# Altium schematic sheet SoC_PS.SchDoc
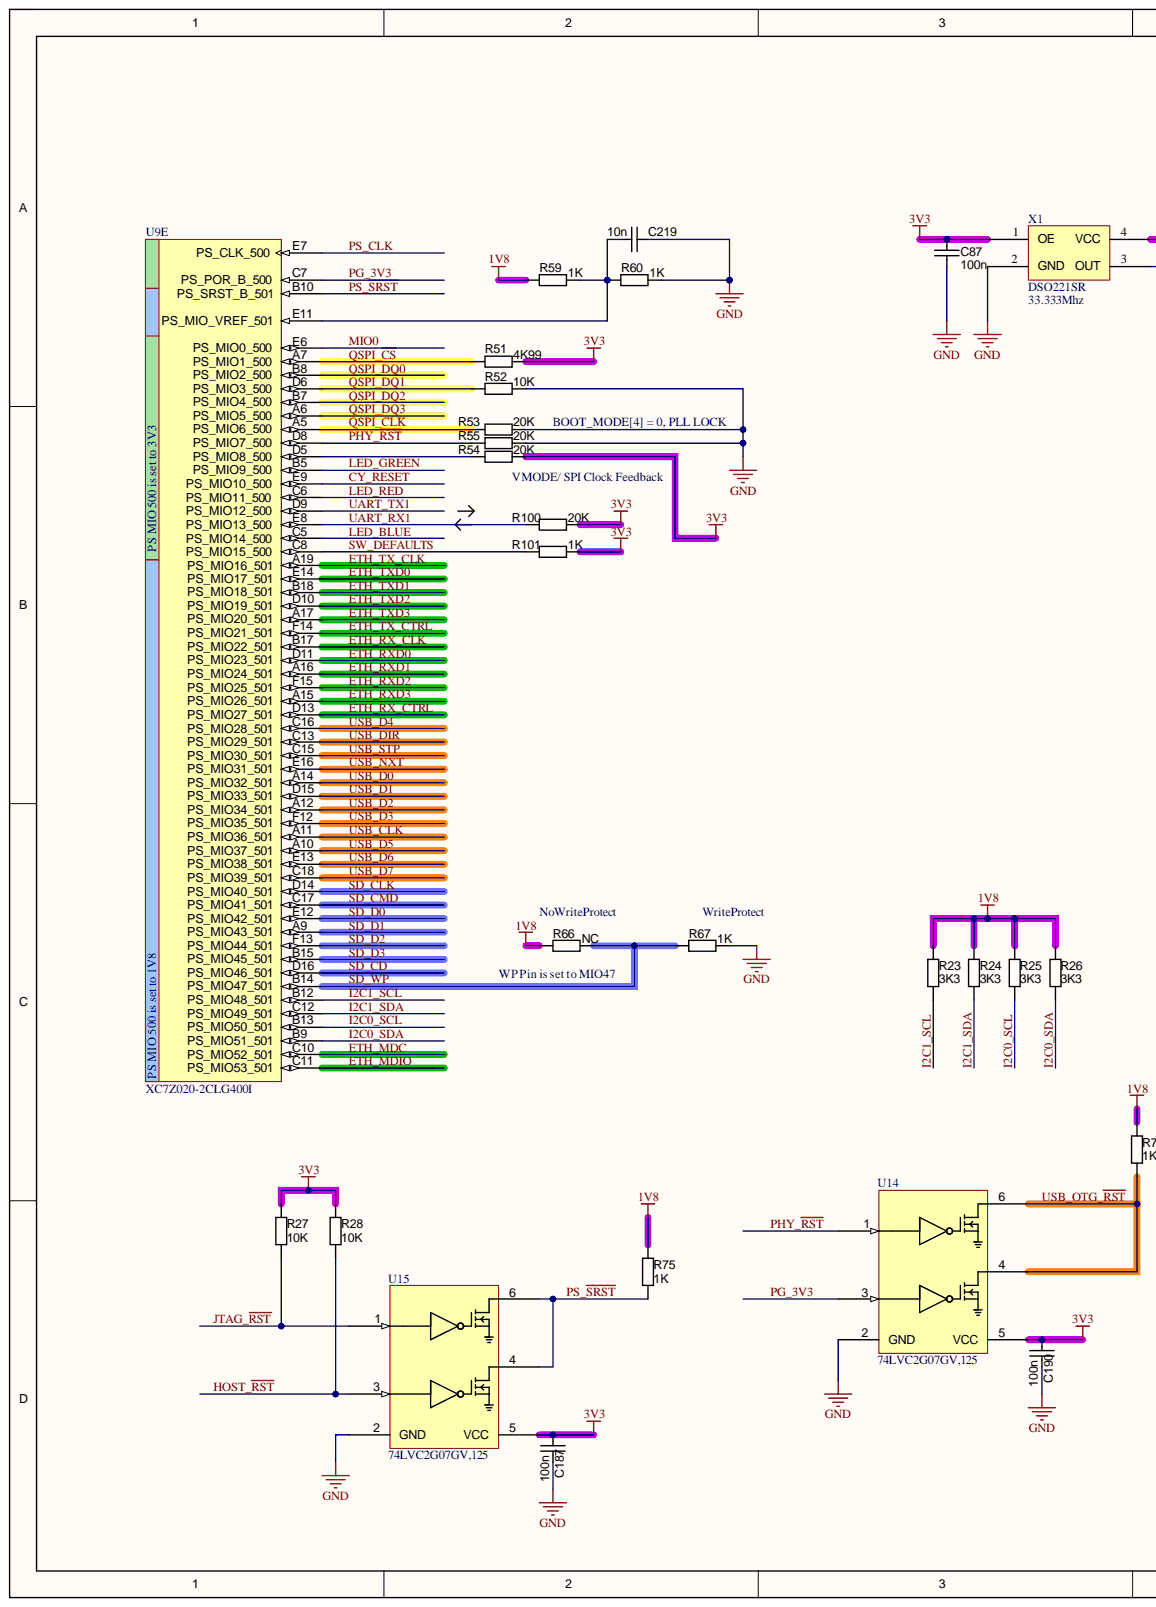
[diagram p0: SoC_PS.SchDoc, left]
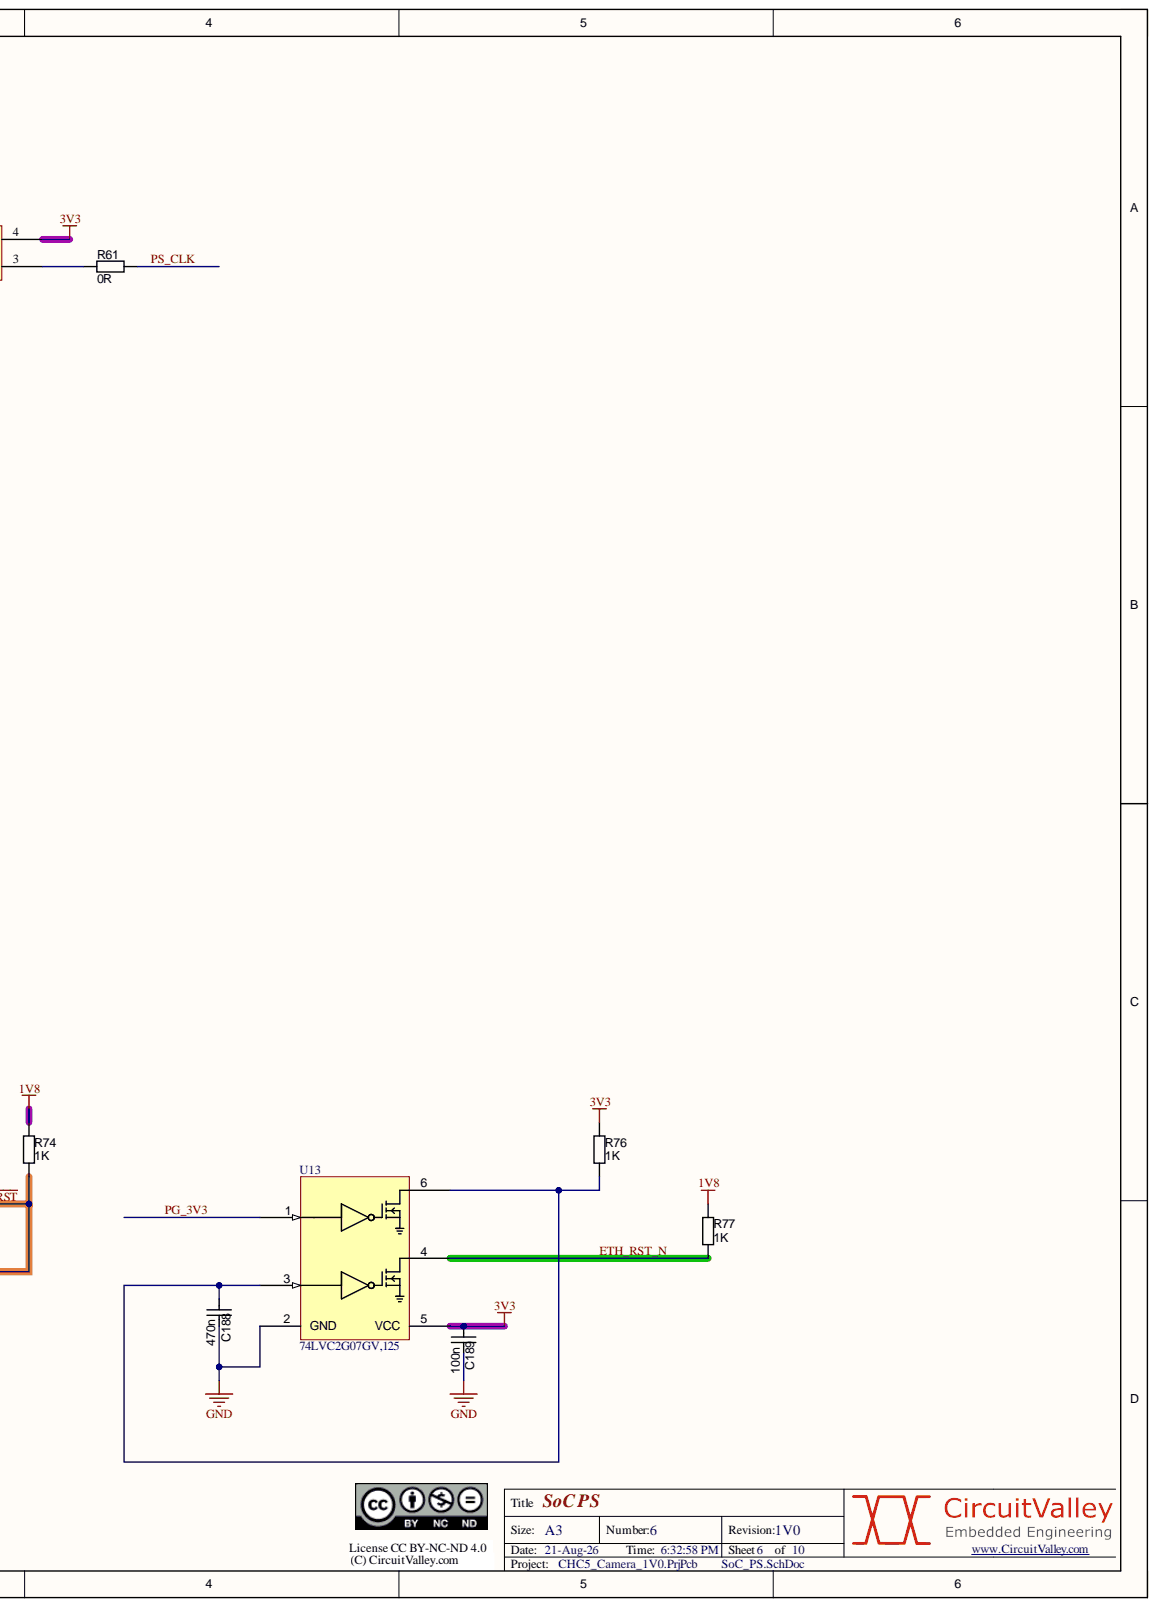
[diagram p1: SoC_PS.SchDoc, right]

## Parts
C87  100n  [CAPC1005N]
C187  100n  [CAPC1005N]
C188  470n  [CAPC1005N]
C189  100n  [CAPC1005N]
C190  100n  [CAPC1005N]
C219  10n  [CAPC1005N]
R23  value 3K3  [RESC1005N]  (hidden comment: Thick Film)
R24  value 3K3  [RESC1005N]  (hidden comment: Thick Film)
R25  value 3K3  [RESC1005N]  (hidden comment: Thick Film)
R26  value 3K3  [RESC1005N]  (hidden comment: Thick Film)
R27  value 10K  [RESC1005N]  (hidden comment: Thick Film)
R28  value 10K  [RESC1005N]  (hidden comment: Thick Film)
R51  value 4K99  [RESC1005N]  (hidden comment: Thick Film)
R52  value 10K  [RESC1005N]  (hidden comment: Thick Film)
R53  value 20K  [RESC1005N]  (hidden comment: Thick Film)
R54  value 20K  [RESC1005N]  (hidden comment: Thick Film)
R55  value 20K  [RESC1005N]  (hidden comment: Thick Film)
R59  value 1K  [RESC1005N]  (hidden comment: Thick Film)
R60  value 1K  [RESC1005N]  (hidden comment: Thick Film)
R61  value 0R  [RESC1005N]  (hidden comment: Thick Film)
R66  value NC  [RESC1005N]  (hidden comment: Thick Film)
R67  value 1K  [RESC1005N]  (hidden comment: Thick Film)
R74  value 1K  [RESC1005N]  (hidden comment: Thick Film)
R75  value 1K  [RESC1005N]  (hidden comment: Thick Film)
R76  value 1K  [RESC1005N]  (hidden comment: Thick Film)
R77  value 1K  [RESC1005N]  (hidden comment: Thick Film)
R100  value 20K  [RESC1005N]  (hidden comment: Thick Film)
R101  value 1K  [RESC1005N]  (hidden comment: Thick Film)
U9  XC7Z020-2CLG400I  [CLG400]  (part E)
U13  74LVC2G07GV,125  [SC74-6]
U14  74LVC2G07GV,125  [SC74-6]
U15  74LVC2G07GV,125  [SC74-6]
X1  DSO221SR  value 33.333Mhz  [Active Oscillator 2520]

## Nets
1V8: R23.2 R24.2 R25.2 R26.2 R59.1 R66.1 R74.2 R75.2 R77.2
3V3: C87.2 C187.2 C189.2 C190.2 R27.2 R28.2 R51.2 R54.2 R76.2 R100.2 R101.2 U13.5(VCC) U14.5(VCC) U15.5(VCC) X1.1(OE) X1.4(VCC)
GND: C87.1 C187.1 C188.1 C189.1 C190.1 C219.1 R52.2 R53.2 R55.2 R60.2 R67.2 U13.2(GND) U14.2(GND) U15.2(GND) X1.2(GND)
CY_RESET: U9.E9(PS_MIO10_500)
ETH_MDC: U9.C10(PS_MIO52_501)
ETH_MDIO: U9.C11(PS_MIO53_501)
ETH_RST_N: R77.1 U13.4
ETH_RX_CLK: U9.B17(PS_MIO22_501)
ETH_RX_CTRL: U9.D13(PS_MIO27_501)
ETH_RXD0: U9.D11(PS_MIO23_501)
ETH_RXD1: U9.A16(PS_MIO24_501)
ETH_RXD2: U9.F15(PS_MIO25_501)
ETH_RXD3: U9.A15(PS_MIO26_501)
ETH_TX_CLK: U9.A19(PS_MIO16_501)
ETH_TX_CTRL: U9.F14(PS_MIO21_501)
ETH_TXD0: U9.E14(PS_MIO17_501)
ETH_TXD1: U9.B18(PS_MIO18_501)
ETH_TXD2: U9.D10(PS_MIO19_501)
ETH_TXD3: U9.A17(PS_MIO20_501)
HOST_~{RST}: R28.1 U15.3
I2C0_SCL: R25.1 U9.B13(PS_MIO50_501)
I2C0_SDA: R26.1 U9.B9(PS_MIO51_501)
I2C1_SCL: R23.1 U9.B12(PS_MIO48_501)
I2C1_SDA: R24.1 U9.C12(PS_MIO49_501)
JTAG_~{RST}: R27.1 U15.1
LED_BLUE: U9.C5(PS_MIO14_500)
LED_GREEN: U9.B5(PS_MIO9_500)
LED_RED: U9.C6(PS_MIO11_500)
MIO0: U9.E6(PS_MIO0_500)
PG_3V3: U9.C7(PS_POR_B_500) U13.1 U14.3
PHY_~{RST}: R55.1 U9.D8(PS_MIO7_500) U14.1
PS_CLK: R61.2 U9.E7(PS_CLK_500)
PS_~{SRST}: R75.1 U9.B10(PS_SRST_B_501) U15.4 U15.6
QSPI_~{CS}: R51.1 U9.A7(PS_MIO1_500)
QSPI_CLK: R53.1 U9.A5(PS_MIO6_500)
QSPI_DQ0: U9.B8(PS_MIO2_500)
QSPI_DQ1: R52.1 U9.D6(PS_MIO3_500)
QSPI_DQ2: U9.B7(PS_MIO4_500)
QSPI_DQ3: U9.A6(PS_MIO5_500)
SD_CD: U9.D16(PS_MIO46_501)
SD_CLK: U9.D14(PS_MIO40_501)
SD_CMD: U9.C17(PS_MIO41_501)
SD_D0: U9.E12(PS_MIO42_501)
SD_D1: U9.A9(PS_MIO43_501)
SD_D2: U9.F13(PS_MIO44_501)
SD_D3: U9.B15(PS_MIO45_501)
SD_WP: R66.2 R67.1 U9.B14(PS_MIO47_501)
SW_DEFAULTS: R101.1 U9.C8(PS_MIO15_500)
UART_RX1: R100.1 U9.E8(PS_MIO13_500)
UART_TX1: U9.D9(PS_MIO12_500)
USB_CLK: U9.A11(PS_MIO36_501)
USB_D0: U9.A14(PS_MIO32_501)
USB_D1: U9.D15(PS_MIO33_501)
USB_D2: U9.A12(PS_MIO34_501)
USB_D3: U9.F12(PS_MIO35_501)
USB_D4: U9.C16(PS_MIO28_501)
USB_D5: U9.A10(PS_MIO37_501)
USB_D6: U9.E13(PS_MIO38_501)
USB_D7: U9.C18(PS_MIO39_501)
USB_DIR: U9.C13(PS_MIO29_501)
USB_NXT: U9.E16(PS_MIO31_501)
USB_OTG_~{RST}: R74.1 U14.4 U14.6
USB_STP: U9.C15(PS_MIO30_501)
NetC188_2: C188.2 R76.1 U13.3 U13.6
NetC219_2: C219.2 R59.2 R60.1 U9.E11(PS_MIO_VREF_501)
NetR54_1: R54.1 U9.D5(PS_MIO8_500)
NetR61_1: R61.1 X1.3(OUT)

## Library data of the parts
C87 C187-C190 C219 = CAPC_10n_0402_16V_10%: Capacitor SMD Cer 10nF 10% 16V X7R 0402; Manufacturer 1: AVX Corporation; Manufacturer Part Number 1: 0402YC103KAT2A; Ratings: 16V; X7R; Component Kind: Standard; ComponentLink1Description: http://www.avx.com/docs/Catalogs/cx7r.pdf; ComponentLink1URL: http://www.avx.com/docs/Catalogs/cx7r.pdf; ComponentLink2Description: http://www.yageo.com/documents/recent/UPY-GPHC_X7R_6.3V-to-50V_8.pdf; ComponentLink2URL: http://www.yageo.com/documents/recent/UPY-GPHC_X7R_6.3V-to-50V_8.pdf; Library Name: PBL - Capacitors.SCHLIB; Manufacturer 2: Yageo; Manufacturer Part Number 2: CC0402KRX7R7BB103; Operating Temperature: -55°C ~ 125°C; Package / Case: 0402 (1005 Metric); Size / Dimension: 0.039" L x 0.020" W (1.00mm x 0.50mm); Supplier 1: Digi-Key; Supplier 2: Digi-Key; Supplier Part Number 1: 478-1114-1-ND; Supplier Part Number 2: 311-1042-1-ND; Tolerance: ±10%
  C87 C187 C189 C190: Value: 100n
  C188: Value: 470n
  C219: Value: 10n
R23-R28 R51-R55 R59-R61 R66 R67 R74-R77 R100 R101 = RES_3K3_0402_5%: Resistor SMD chip 3.3k Ohm 0.063W 5% 0402; Manufacturer 1: Yageo; Manufacturer Part Number 1: RC0402JR-073K3L; Ratings: 1/16 W; Component Kind: Standard; ComponentLink1Description: http://www.yageo.com/documents/recent/PYu-RC0402_51_RoHS_L_6.pdf; ComponentLink1URL: http://www.yageo.com/documents/recent/PYu-RC0402_51_RoHS_L_6.pdf; Library Name: PBL - Resistors.SCHLIB; Material: Thick Film; Package / Case: 0402 (1005 metric); Supplier 1: Digi-Key; Supplier Part Number 1: 311-3.3KJRCT-ND; Tolerance: ±5%
U9 = XC7Z020-2CLG400I: 733 MHz Zynq™-7000 AP SoC, 130 PS I/O, 125 FPGA I/O, 400-Ball BGA, Speed Grade 2, Industrial Grade, Pb-Free; Manufacturer: Xilinx; Extensible Block RAM (# 36Kb Blocks): 560 KB (140); Flip Flops: 106400; LUTs: 53200; Speed Grade: -2; Code_JEDEC: MO-275-MMAA-1; Component Kind: Standard; ComponentLink1Description: Datasheet; ComponentLink1URL: http://www.xilinx.com/support/documentation/data_sheets/ds190-Zynq-7000-Overview.pdf; ComponentLink2Description: Package Specification; ComponentLink2URL: http://www.xilinx.com/support/documentation/user_guides/ug865-Zynq-7000-Pkg-Pinout.pdf; ComponentLink3Description: DC and AC Switching Characteristics; ComponentLink3URL: http://www.xilinx.com/support/documentation/data_sheets/ds187-XC7Z010-XC7Z020-Data-Sheet.pdf; ComponentLink4Description: Package File; ComponentLink4URL: http://www.xilinx.com/support/packagefiles/z7packages/xc7z020clg400pkg.txt; ComponentLink5Description: Packaging and Pinout; ComponentLink5URL: http://www.xilinx.com/support/documentation/user_guides/ug865-Zynq-7000-Pkg-Pinout.pdf; ComponentLink6Description: PCB and Layout Guide; ComponentLink6URL: http://www.xilinx.com/support/documentation/user_guides/ug483_7Series_PCB.pdf; DatasheetVersion: v1.2; Generic Part Number: XC7Z020; Library Name: Xilinx Zynq-7000.SchLib; Mounting Technology: Surface Mount; PackageDescription: Wire-Bond Chip-Scale BGA, Body 17 x 17 mm, 0.8 mm Pitch; PackageReference: CLG400; PackageVersion: Rev 1.1; PartNumber: XC7Z020-2CLG400I; RoHS: Pb-Free
X1 = Oscillator_2520: Active Oscillator_2520; Component Kind: Standard; Library Name: Crystal_and_oscillator.SchLib
U13-U15 = 74LVC2G07GV,125: Component Kind: Standard; Library Name: cvlib.SchLib
